# Altium schematic sheet PWR1.SchDoc(PWR1)
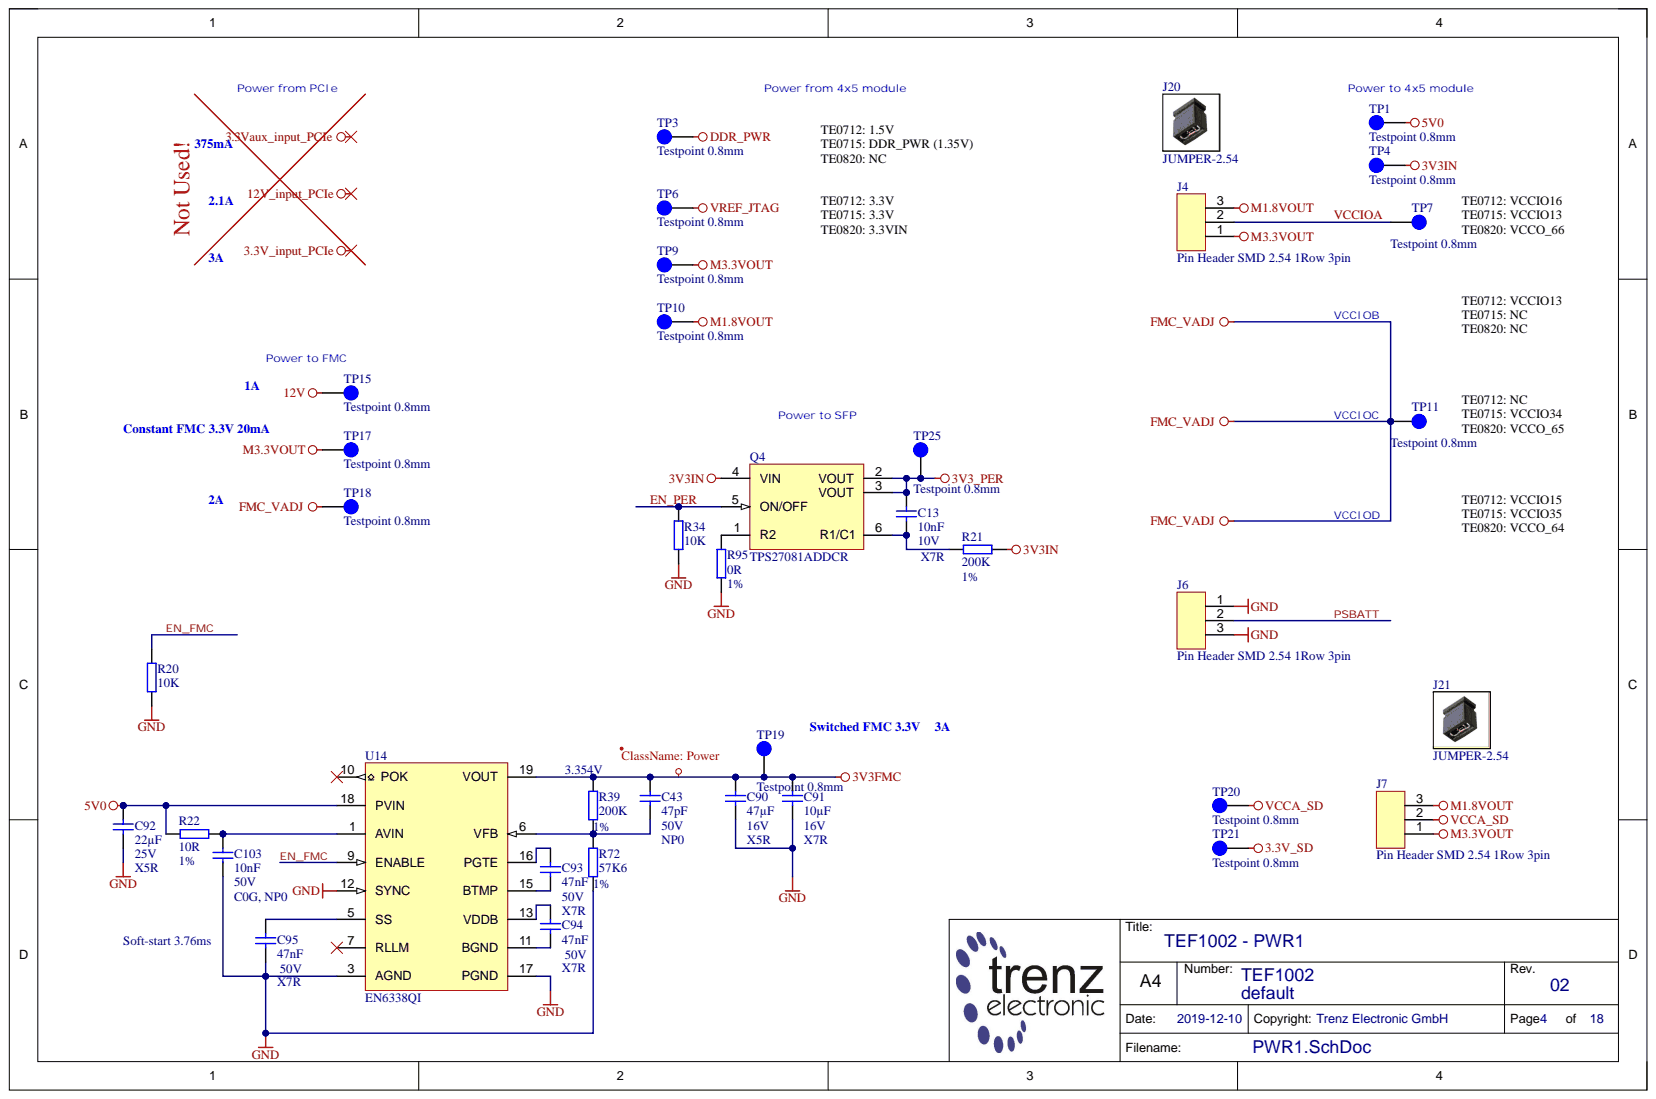
[diagram p0: PWR1.SchDoc]

## Parts
C13  10nF  Voltage: 10V  [CAPC0603X33N]
C43  47pF  Technology: NP0  Voltage: 50V  [CAPC1005X55N]
C90  47µF  Technology: X5R  Voltage: 16V  [CAPC3216X180N]
C91  10µF  Technology: X7R  Voltage: 16V  [CAPC2012X135N]
C92  22µF  Technology: X5R  Voltage: 25V  [CAPC3216X180N]
C93  47nF  Voltage: 50V  [CAPC1005X55N]
C94  47nF  Technology: X7R  Voltage: 50V  [CAPC1005X55N]
C95  47nF  Technology: X7R  Voltage: 50V  [CAPC1005X55N]
C103  10nF  Voltage: 50V  [CAPC1608X90N]
J4  Pin Header SMD 2.54 1Row 3pin  [TSM-103-01-F-SV]
J6  Pin Header SMD 2.54 1Row 3pin  [TSM-103-01-F-SV]
J7  Pin Header SMD 2.54 1Row 3pin  [TSM-103-01-F-SV]
J20  JUMPER-2.54  (mechanical)
J21  JUMPER-2.54  (mechanical)
Q4  TPS27081ADDCR  [SOT95P280X110-6N]
R20  10K  [RESC1005X40N]
R21  200K  Tolerance: 1%  [RESC1005X40N]
R22  10R  Tolerance: 1%  [RESC1005X40N]
R34  10K  [RESC1005X40N]
R39  200K  Tolerance: 1%  [RESC1005X40N]
R72  57K6  Tolerance: 1%  [RESC1005X40N]
R95  0R  Tolerance: 1%  [RESC1005X40N]
TP1  Testpoint 0.8mm  [TP_0.8mm]
TP3  Testpoint 0.8mm  [TP_0.8mm]
TP4  Testpoint 0.8mm  [TP_0.8mm]
TP6  Testpoint 0.8mm  [TP_0.8mm]
TP7  Testpoint 0.8mm  [TP_0.8mm]
TP9  Testpoint 0.8mm  [TP_0.8mm]
TP10  Testpoint 0.8mm  [TP_0.8mm]
TP11  Testpoint 0.8mm  [TP_0.8mm]
TP15  Testpoint 0.8mm  [TP_0.8mm]
TP17  Testpoint 0.8mm  [TP_0.8mm]
TP18  Testpoint 0.8mm  [TP_0.8mm]
TP19  Testpoint 0.8mm  [TP_0.8mm]
TP20  Testpoint 0.8mm  [TP_0.8mm]
TP21  Testpoint 0.8mm  [TP_0.8mm]
TP25  Testpoint 0.8mm  [TP_0.8mm]
U14  EN6338QI  [EN6338QI]

## Nets
3.3V_SD: TP21.1
3V3_PER: C13.1 Q4.2(VOUT) Q4.3(VOUT) TP25.1
3V3FMC: C43.1 C90.1 C91.2 R39.1 TP19.1 U14.19(VOUT)
3V3IN: Q4.4(VIN) R21.2 TP4.1
5V0: C92.1 R22.1 TP1.1 U14.18(PVIN)
12V: TP15.1
DDR_PWR: TP3.1
FMC_VADJ: TP11.1 TP18.1
GND: C90.2 C91.1 C92.2 C95.2 C103.2 J6.1 J6.3 R20.1 R34.1 R72.2 R95.1 U14.3(AGND) U14.12(SYNC) U14.17(PGND)
M1.8VOUT: J4.3 J7.3 TP10.1
M3.3VOUT: J4.1 J7.1 TP9.1 TP17.1
VCCA_SD: J7.2 TP20.1
VREF_JTAG: TP6.1
EN_FMC: R20.2 U14.9(ENABLE)
EN_PER: Q4.5(ON/OFF) R34.2
PSBATT: J6.2
VCCIOA: J4.2 TP7.1
NetC13_2: C13.2 Q4.6(R1/C1) R21.1
NetC43_2: C43.2 R39.2 R72.1 U14.6(VFB)
NetC93_1: C93.1 U14.16(PGTE)
NetC93_2: C93.2 U14.15(BTMP)
NetC94_1: C94.1 U14.13(VDDB)
NetC94_2: C94.2 U14.11(BGND)
NetC95_1: C95.1 U14.5(SS)
NetC103_1: C103.1 R22.2 U14.1(AVIN)
NetQ4_1: Q4.1(R2) R95.2
3.3V_input_PCIe:
3.3Vaux_input_PCIe:
12V_input_PCIe:

Not connected: U14 7(RLLM) 10(POK)

## Library data of the parts
C13 = 26312: KON 10nF 10V X7R 10% SMD BF 0603 (0201); Database Table Name: C_0603_0201; Mixed: SMT; Preferred: Yes; Temperature-Range: -55/+125; Value: 10n; AN: 26312; Case: 0603 (0201); Component Kind: Standard; Library Name: TE.DbLib; Technology: X7R; Tolerance: 10%
C43 = 24885: KON 47pF 50V NP0 SMD BF 1005 (0402); Database Table Name: C_1005_0402; Mixed: SMT; Preferred: Yes; Value: 47p; AN: 24885; Case: 1005 (0402); Component Kind: Standard; Library Name: TE.DbLib
C90 = 24955: KON 47µF 16V  X5R 20% SMD BF 3216 (1206); Database Table Name: C_3216_1206; Mixed: SMT; Preferred: Yes; Temperature-Range: -55/+85; Value: 47u; AN: 24955; Case: 3216 (1206); Component Kind: Standard; Library Name: TE.DbLib; Tolerance: 20%
C91 = 24380: KON 10µF 16V X7R 10% SMD BF 2012 (0805); Database Table Name: C_2012_0805; Mixed: SMT; Preferred: Yes; Temperature-Range: -55/+125; Value: 10u; AN: 24380; Case: 2012 (0805); Component Kind: Standard; Library Name: TE.DbLib; Tolerance: 10%
C92 = 24886: KON 22µF X5R 25V 10%  SMD BF 3216 (1206); Database Table Name: C_3216_1206; Mixed: SMT; Preferred: Yes; Temperature-Range: -55/+85; Value: 22u; AN: 24886; Case: 3216 (1206); Component Kind: Standard; Library Name: TE.DbLib; Tolerance: 10%
C93-C95 = 24684: KON 47nF 50V X7R 10% SMD BF 1005 (0402); Database Table Name: C_1005_0402; Mixed: SMT; Temperature-Range: -55/+125; Value: 47n; AN: 24684; Case: 1005 (0402); Component Kind: Standard; Library Name: TE.DbLib; Tolerance: 10%
  C93: Technology: X7R
C103 = 26587: KON 10nF 50V NP0 SMD BF 1608 (0603); Database Table Name: C_1608_0603; H-ART: C1608C0G1H103J080AA; Mixed: SMT; Value: 10n; AN: 26587; Case: 1608 (0603); Component Kind: Standard; Library Name: TE.DbLib; Technology: C0G, NP0
J4 J6 J7 = 29447: Stiftleiste 1-reihig, 3pol. SMD; Database Table Name: CON_HEADER; Mixed: SMT; Temperature-Range: -55/+125; AN: 29447; Case: TSM; Component Kind: Standard; Library Name: TE.DbLib; HelpURL: b:\AD\adlib\datasheet\Samtec_TSM\
J20 J21 = 20996: Jumper 2,54mm Raster, Schwarz offen; Database Table Name: CON_HEADER; AN: 20996; Library Name: TE.DbLib
Q4 = 29262: Database Table Name: SWITCH; Mixed: SMT; Temperature-Range: -40/+85; AN: 29262; Case: SOT23-6; Component Kind: Standard; Description: TPS27081ADDCR; H-ART: TPS27081ADDCR; Library Name: TE.DbLib; HelpURL: b:\AD\adlib\datasheet\TPS27081A\
R20 R34 = 22750: WID SMD 10K 1% 0,063W BF 1005 (0402); Database Table Name: R_1005_0402; H-ART: RC0402FR-0710KL; Mixed: SMT; Preferred: Yes; AN: 22750; Case: 1005 (0402); Component Kind: Standard; Library Name: TE.DbLib; Technology: TK100; Tolerance: 1%; Value: 10K
R21 R39 = 23846: WID SMD 200K 1% 0,063W  BF 1005 (0402); Database Table Name: R_1005_0402; H-ART: RC0402FR-07200KL; Mixed: SMT; Preferred: Yes; AN: 23846; Case: 1005 (0402); Component Kind: Standard; Library Name: TE.DbLib; Technology: TK100; Value: 200K
R22 = 22844: WID SMD 10R 1% 0,063W  BF 1005 (0402); Database Table Name: R_1005_0402; H-ART: RC0402FR-0710RL; Mixed: SMT; Preferred: Yes; Value: 10; AN: 22844; Case: 1005 (0402); Component Kind: Standard; Library Name: TE.DbLib; Technology: TK100
R72 = 24958: WID SMD 57K6 1% 0,063W  BF 1005 (0402); Database Table Name: R_1005_0402; Mixed: SMT; Preferred: Yes; Value: 57.6K; AN: 24958; Case: 1005 (0402); Component Kind: Standard; Library Name: TE.DbLib; Technology: TK100
R95 = 22738: WID SMD 0R  BF 1005 (0402); Database Table Name: R_1005_0402; H-ART: RC0402JR-070RL; Mixed: SMT; Preferred: Yes; Value: 0; AN: 22738; Case: 1005 (0402); Component Kind: Standard; Library Name: TE.DbLib; Technology: TK100
TP1 TP3 TP4 TP6 TP7 TP9-TP11 TP15 TP17-TP21 TP25 = 26289: Database Table Name: PCB; Mixed: MECH; AN: 26289; Component Kind: Standard; Description: Testpoint 0.8mm; Library Name: TE.DbLib
U14 = 29249: Database Table Name: DCDC; Mixed: SMT; Temperature-Range: -40/+125 (Tj); AN: 29249; Component Kind: Standard; Description: EN6338QI; H-ART: EN6338QI; Library Name: TE.DbLib; HelpURL: b:\AD\adlib\datasheet\EN6338QI\
